# Altium schematic sheet VREG_CCU_HAS.SchDoc
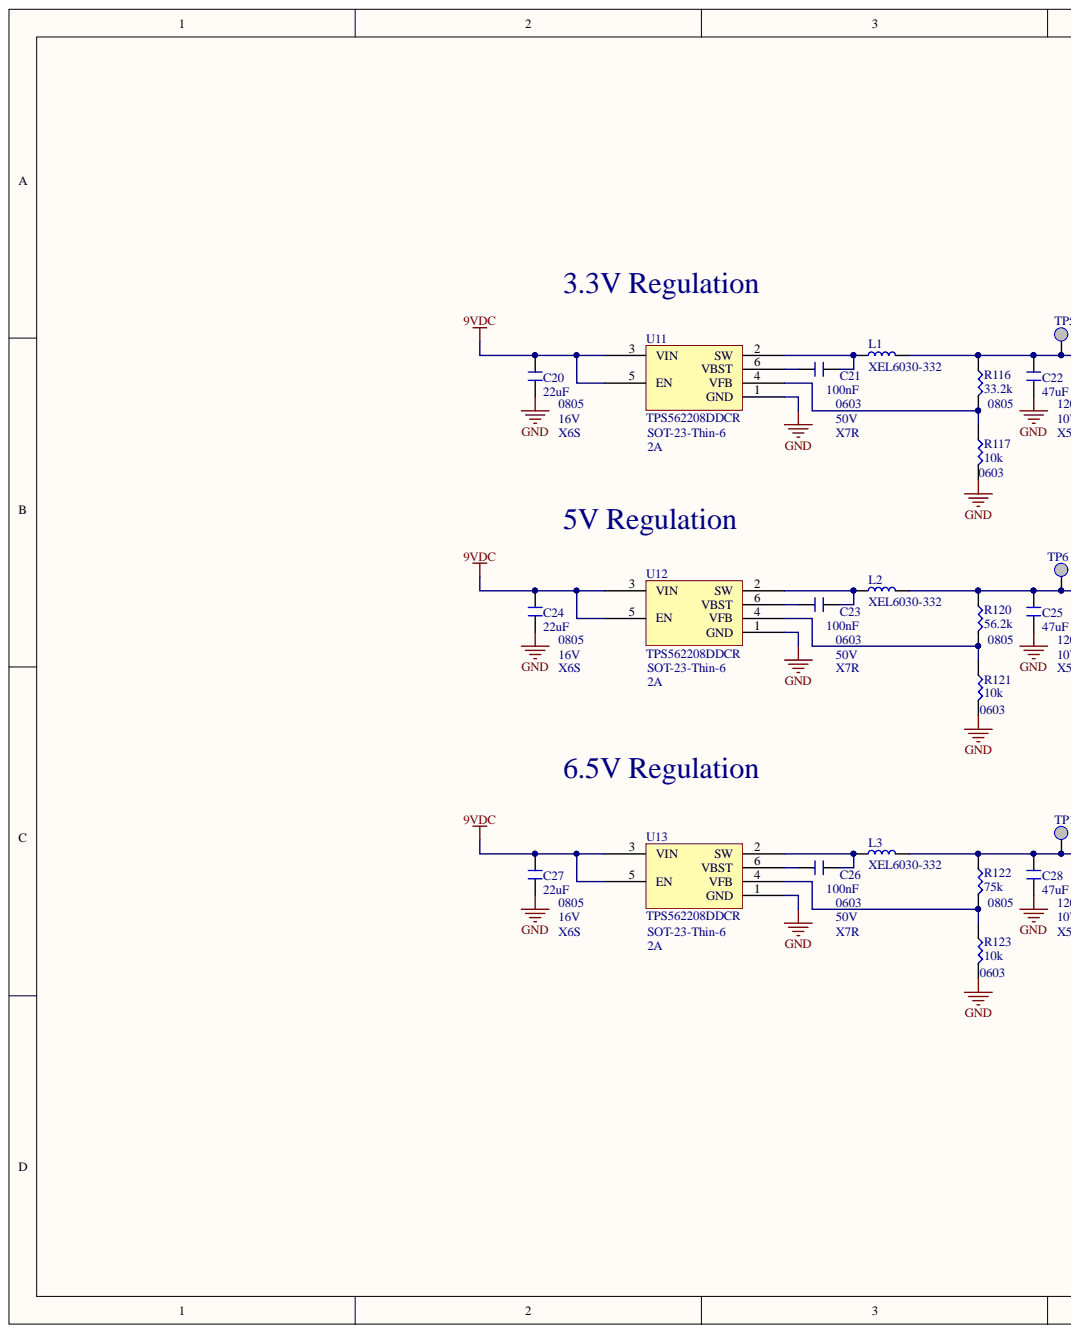
[diagram p0: VREG_CCU_HAS.SchDoc, left]
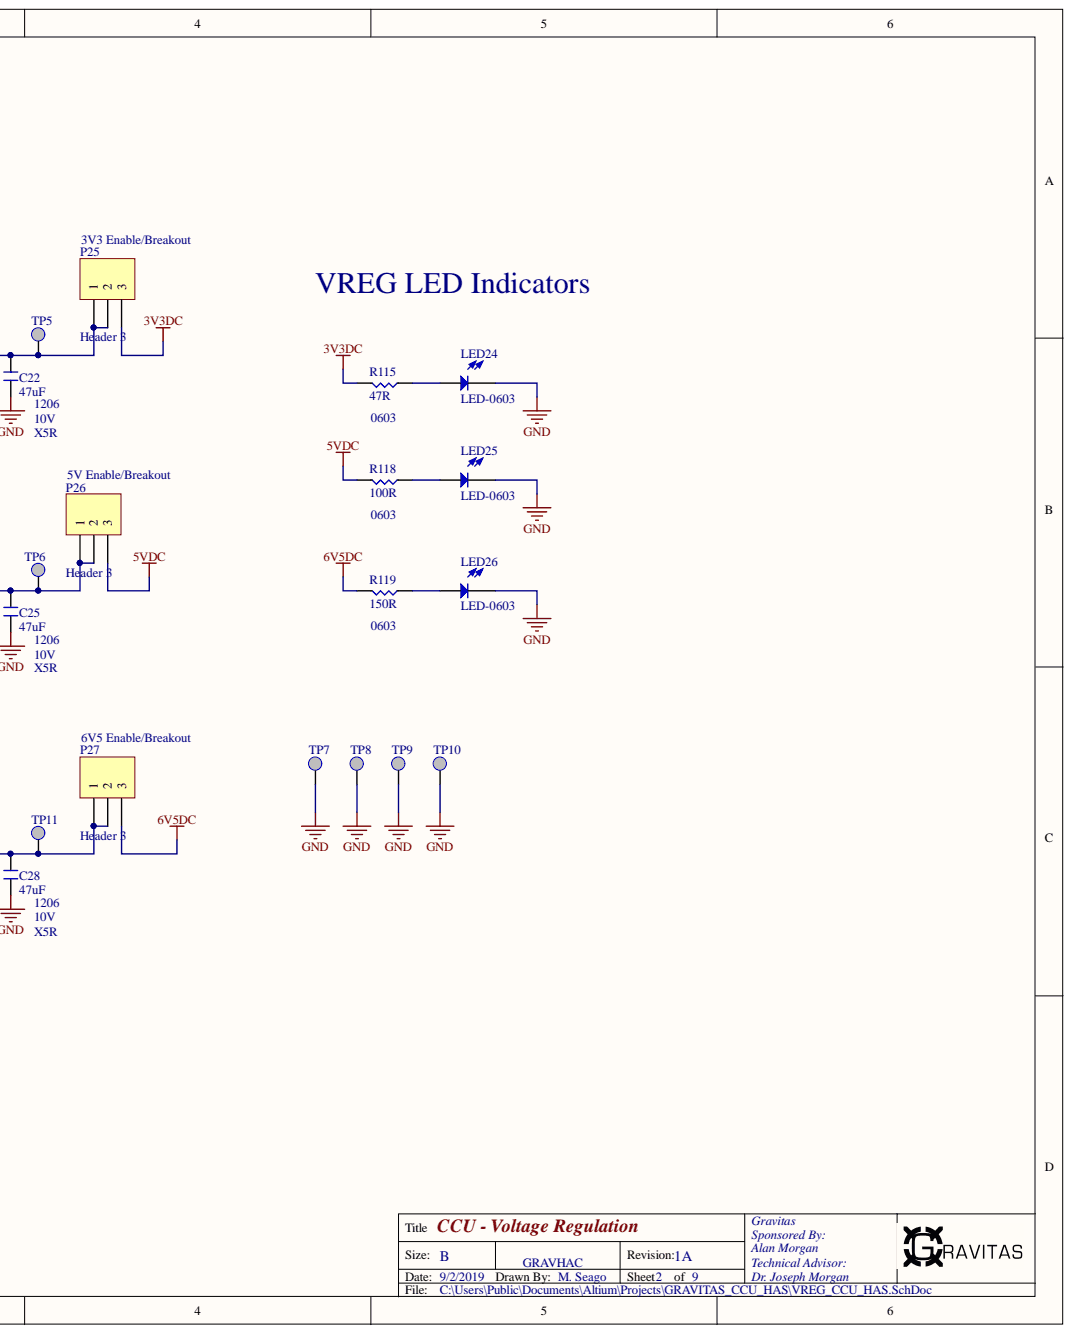
[diagram p1: VREG_CCU_HAS.SchDoc, right]

## Parts
C20  22uF  [NonPolar-0805]
C21  100nF  [NonPolar-0603]
C22  47uF  [NONPOLAR-1206]
C23  100nF  [NonPolar-0603]
C24  22uF  [NonPolar-0805]
C25  47uF  [NONPOLAR-1206]
C26  100nF  [NonPolar-0603]
C27  22uF  [NonPolar-0805]
C28  47uF  [NONPOLAR-1206]
L1  XEL6030-332  [XEL6030-33uH]
L2  XEL6030-332  [XEL6030-33uH]
L3  XEL6030-332  [XEL6030-33uH]
LED24  LED-0603  [LED-0603]
LED25  LED-0603  [LED-0603]
LED26  LED-0603  [LED-0603]
P25  Header 3  [HDR1X3]
P26  Header 3  [HDR1X3]
P27  Header 3  [HDR1X3]
R115  47R  [NonPolar-0603]
R116  33.2k  [NonPolar-0805]
R117  10k  [NonPolar-0603]
R118  100R  [NonPolar-0603]
R119  150R  [NonPolar-0603]
R120  56.2k  [NonPolar-0805]
R121  10k  [NonPolar-0603]
R122  75k  [NonPolar-0805]
R123  10k  [NonPolar-0603]
TP5  TestPoint  [TP - S2751-46R]  (value not drawn)
TP6  TestPoint  [TP - S2751-46R]  (value not drawn)
TP7  TestPoint  [TP - S2751-46R]  (value not drawn)
TP8  TestPoint  [TP - S2751-46R]  (value not drawn)
TP9  TestPoint  [TP - S2751-46R]  (value not drawn)
TP10  TestPoint  [TP - S2751-46R]  (value not drawn)
TP11  TestPoint  [TP - S2751-46R]  (value not drawn)
U11  TPS562208DDCR  [TPS562208DDCR]
U12  TPS562208DDCR  [TPS562208DDCR]
U13  TPS562208DDCR  [TPS562208DDCR]

## Nets
3V3DC: P25.3 R115.1
5VDC: P26.3 R118.1
6V5DC: P27.3 R119.1
9VDC: C20.2 C24.2 C27.2 U11.3(VIN) U11.5(EN) U12.3(VIN) U12.5(EN) U13.3(VIN) U13.5(EN)
GND: C20.1 C22.1 C24.1 C25.1 C27.1 C28.1 LED24.2 LED25.2 LED26.2 R117.1 R121.1 R123.1 TP7.1 TP8.1 TP9.1 TP10.1 U11.1(GND) U12.1(GND) U13.1(GND)
NetC21_1: C21.1 U11.6(VBST)
NetC21_2: C21.2 L1.1 U11.2(SW)
NetC22_2: C22.2 L1.2 P25.1 P25.2 R116.2 TP5.1
NetC23_1: C23.1 U12.6(VBST)
NetC23_2: C23.2 L2.1 U12.2(SW)
NetC25_2: C25.2 L2.2 P26.1 P26.2 R120.2 TP6.1
NetC26_1: C26.1 U13.6(VBST)
NetC26_2: C26.2 L3.1 U13.2(SW)
NetC28_2: C28.2 L3.2 P27.1 P27.2 R122.2 TP11.1
NetLED24_1: LED24.1 R115.2
NetLED25_1: LED25.1 R118.2
NetLED26_1: LED26.1 R119.2
NetR116_1: R116.1 R117.2 U11.4(VFB)
NetR120_1: R120.1 R121.2 U12.4(VFB)
NetR122_1: R122.1 R123.2 U13.4(VFB)

## Library data of the parts
C20 C24 C27 = Cap - 0805: Component Kind: Standard; Library Name: GravLib.IntLib
C21 C23 C26 = Cap - 0603: Component Kind: Standard; Library Name: GravLib.IntLib
C22 C25 C28 = Cap - 1206: Component Kind: Standard; Library Name: GravLib.IntLib
P25-P27 = Header 3: Header, 3-Pin; Component Kind: Standard; LatestRevisionDate: 17-Jul-2002; LatestRevisionNote: Re-released for DXP Platform.; Library Name: Miscellaneous Connectors.IntLib; Signal Integrity: Connector; Publisher: Altium Limited
R115 R117-R119 R121 R123 = Res - 0603: Component Kind: Standard; Library Name: GravLib.IntLib
R116 R120 R122 = Res - 0805: Component Kind: Standard; Library Name: GravLib.IntLib
TP5-TP11 = TestPoint: S2751-46R; Component Kind: Standard; Library Name: GravLib.IntLib
U11-U13 = TPS562208DDCR: 4.5V to 17V Input, 2A Output, Synchronous Step-Down Converter; Component Kind: Standard; Library Name: GravLib.IntLib
L1-L3 = XEL6030-332: Component Kind: Standard; Library Name: GravLib.IntLib
LED24-LED26 = LED-0603: Component Kind: Standard; Library Name: GravLib.IntLib
